# Altium schematic sheet PN532.SchDoc(PN532)
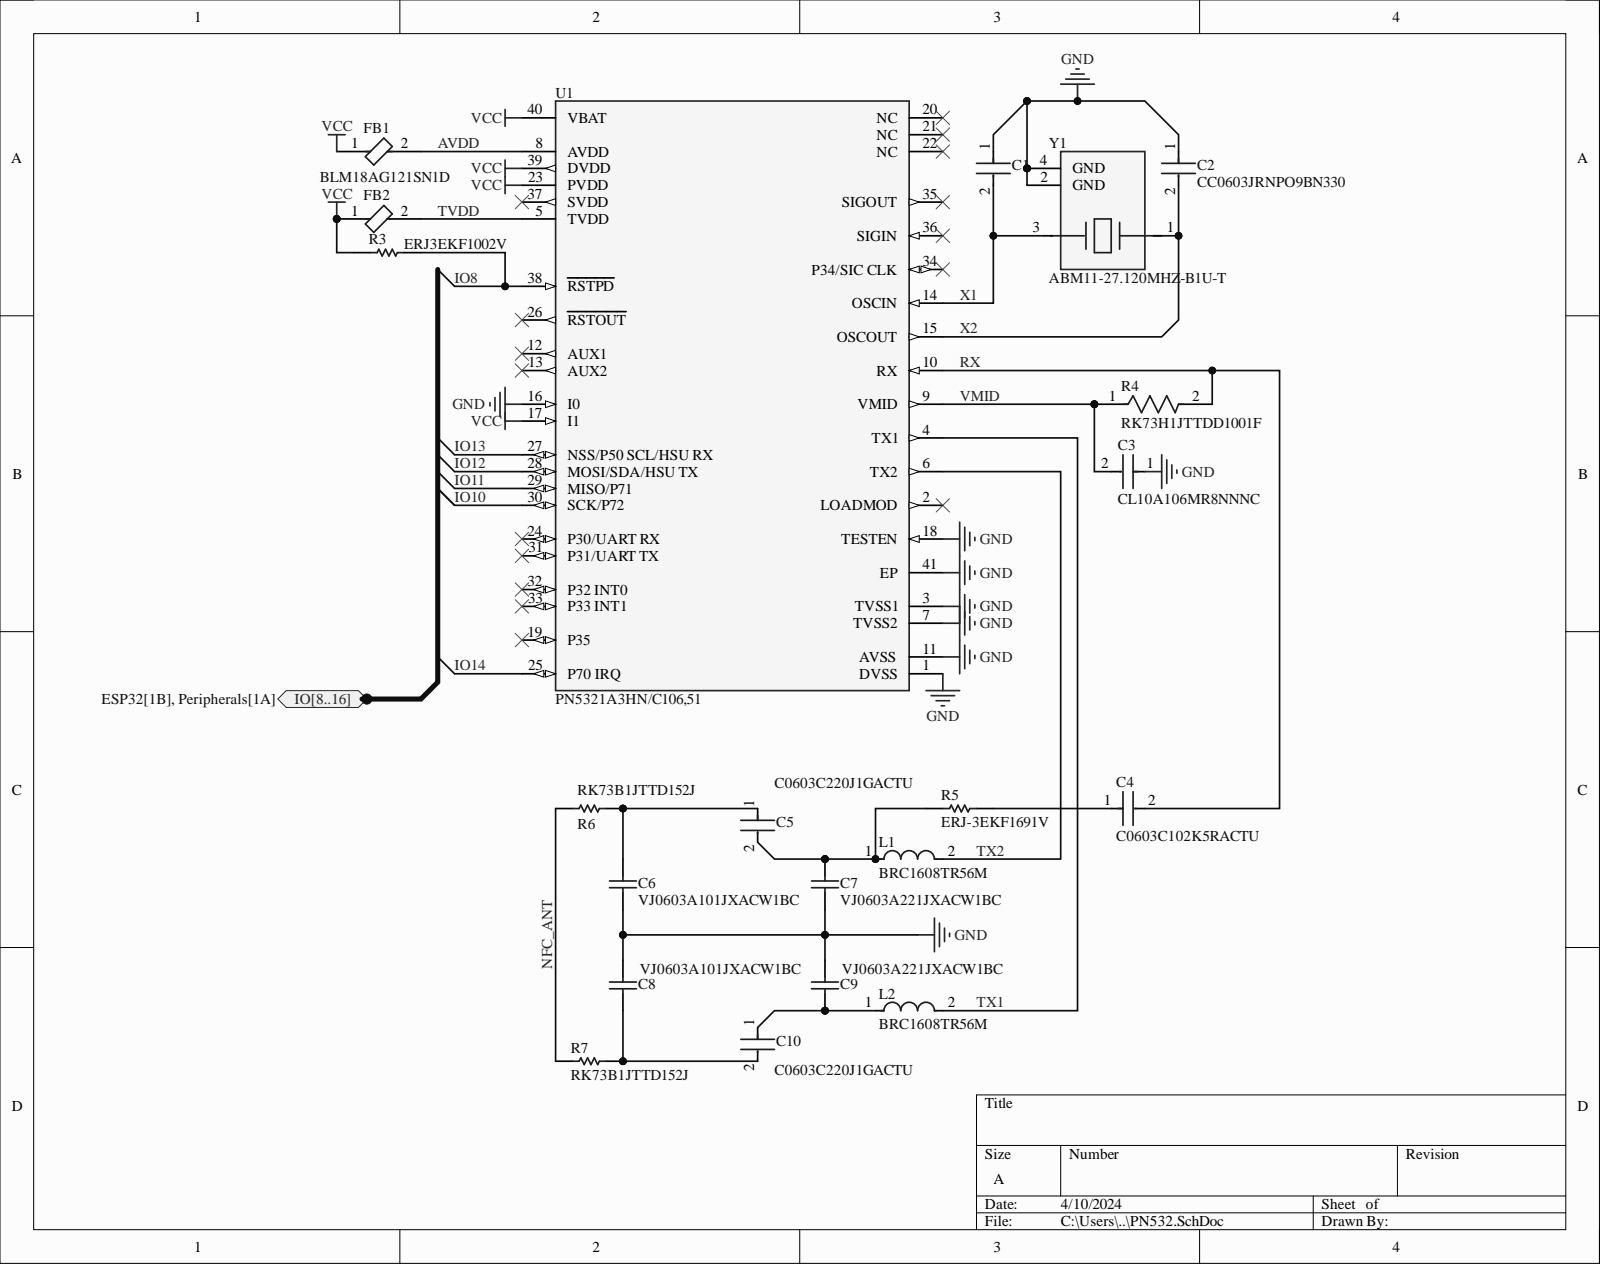
[diagram p0: PN532.SchDoc]

## Parts
C1  CC0603JRNPO9BN330  [FP-CC0603-0_9-IPC_C]
C2  CC0603JRNPO9BN330  [FP-CC0603-0_9-IPC_C]
C3  CL10A106MR8NNNC  [FP-CL10-IPC_C]
C4  C0603C102K5RACTU  [FP-C0603C-CF-MFG]
C5  C0603C220J1GACTU  [FP-C0603C-CF-MFG]
C6  VJ0603A101JXACW1BC  [CAPC1608X95X40ML15T15]
C7  VJ0603A221JXACW1BC  [CAPC1608X95X40ML15T15]
C8  VJ0603A101JXACW1BC  [CAPC1608X95X40ML15T15]
C9  VJ0603A221JXACW1BC  [CAPC1608X95X40ML15T15]
C10  C0603C220J1GACTU  [FP-C0603C-CF-MFG]
FB1  BLM18AG121SN1D  [FP-BLM18-0_15-t0_8-IPC_B]
FB2  BLM18AG121SN1D  [FP-BLM18-0_15-t0_8-IPC_B]
L1  BRC1608TR56M  [FP-BRC1608-MFG]
L2  BRC1608TR56M  [FP-BRC1608-MFG]
R3  ERJ3EKF1002V  [RESC1609X55X30LL15T15]
R4  RK73H1JTTDD1001F  [FP-RK73H1J-IPC_A]
R5  ERJ-3EKF1691V  [RESC1608X55X30NL15T15]
R6  RK73B1JTTD152J  [RESC1608X55X30LL20T10]
R7  RK73B1JTTD152J  [RESC1608X55X30LL20T10]
U1  PN5321A3HN/C106,51  [FP-SOT618-1-MFG]
Y1  ABM11-27.120MHZ-B1U-T  [FP-ABM11-MFG]

## Ports
IO8, IO9, IO10, IO11, IO12, IO13, IO14, IO15, IO16, IO[8..16]

## Nets
GND: C1.1 C2.1 C3.1 C6.1 C7.1 C8.2 C9.2 U1.1(DVSS) U1.3(TVSS1) U1.7(TVSS2) U1.11(AVSS) U1.16(I0) U1.18(TESTEN) U1.41(EP) Y1.2(GND) Y1.4(GND)
VCC: FB1.1 FB2.1 R3.2 U1.17(I1) U1.23(PVDD) U1.39(DVDD) U1.40(VBAT)
AVDD: FB1.2 U1.8(AVDD)
IO8: R3.1 U1.38(RSTPD) ; port IO[8..16]  [also labelled ]
IO10: U1.30(SCK/P72) ; port IO[8..16]  [also labelled ]
IO11: U1.29(MISO/P71) ; port IO[8..16]  [also labelled ]
IO12: U1.28(MOSI/SDA/HSU_TX) ; port IO[8..16]  [also labelled ]
IO13: U1.27(NSS/P50_SCL/HSU_RX) ; port IO[8..16]  [also labelled ]
IO14: U1.25(P70_IRQ) ; port IO[8..16]  [also labelled ]
NFC_ANT: R6.1 R7.1
RX: C4.2 R4.2 U1.10(RX)
TVDD: FB2.2 U1.5(TVDD)
TX1: L2.2 U1.4(TX1)
TX2: L1.2 U1.6(TX2)
VMID: C3.2 R4.1 U1.9(VMID)
X1: C1.2 U1.14(OSCIN) Y1.3
X2: C2.2 U1.15(OSCOUT) Y1.1
NetC4_1: C4.1 R5.1
NetC5_1: C5.1 C6.2 R6.2
NetC5_2: C5.2 C7.2 L1.1 R5.2
NetC8_1: C8.1 C10.2 R7.2
NetC9_1: C9.1 C10.1 L2.1
N00001_9: ; port IO[8..16]
N00001_15: ; port IO[8..16]
N00001_16: ; port IO[8..16]

Not connected: U1 2(LOADMOD) 12(AUX1) 13(AUX2) 19(P35) 20(NC) 21(NC) 22(NC) 24(P30/UART_RX) 26(RSTOUT) 31(P31/UART_TX) 32(P32_INT0) 33(P33_INT1) 34(P34/SIC_CLK) 35(SIGOUT) 36(SIGIN) 37(SVDD)

## Library data of the parts
C1 C2 = CMP-2000-06072-2: CAP CER 33PF 50V C0G/NPO 0603; Dielectric: C0G; Dielectric Material: Ceramic; Insulation Resistance: 100GR; Temperature Coefficient: 30ppm/°C; Tolerance: 5%; Capacitance: 33pF; Case Code (Imperial): 0603; Case Code (Metric): 1608; Case/Package: 0603; Component Kind: Standard; Depth: 0.8mm; Height: 0.8mm; Lead Free: Lead Free; Length: 1.6mm; Library Name: Altium Content Vault; Max Operating Temperature: 125°C; Min Operating Temperature: -55°C; Mount: Surface Mount; Number of Pins: 2; Package Quantity: 1; Packaging: Tape and Reel; Radiation Hardening: No; REACH SVHC: No SVHC; Resistor Type: Ceramic; RoHS Compliant: Yes; Series: CC; Termination: SMD/SMT; Thickness: 0.035inch; Voltage: 50V; Voltage Rating: 50V; Voltage Rating (DC): 50V; Width: 0.031inch
C3 = CMP-13271-001990-1: Cap Ceramic 10uF 4V X5R ±20% Pad SMD 0603 +85°C T/R; Capacitance: 10uF; Case Code (Imperial): 0603; Case Code (Metric): 1608; Case/Package: 0603; Component Kind: Standard; Depth: 0.8mm; Dielectric: X5R; Height: 0.8mm; Lead Free: Lead Free; Length: 1.6mm; Library Name: Altium Content Vault; Max Operating Temperature: 85°C; Min Operating Temperature: -55°C; Mount: Surface Mount; Package Quantity: 1; Packaging: Tape and Reel; Radiation Hardening: No; Thickness: 0.035inch; Tolerance: 20%; Voltage Rating: 4V; Voltage Rating (DC): 4V; Width: 0.031inch
C4 = CMP-2006-03022-3: CAP CER 1000PF 50V X7R 0603; Manufacturer: KEMET; Automotive Grade: Grade 1; Capacitance: 1,000 pF; Material: Ceramic; Temperature Coefficient: 30ppm/°C; Tolerance: 0.1; Value: 1,000 pF; Automotive: Yes; Category: Cap; Component Kind: Standard; ComponentLink2Description: Datasheet; ComponentLink2URL: https://api.kemet.com/component-edge/download/datasheet/C0603C100J5GACAUTO.pdf; Device Class L1: Passive Components; Device Class L2: Capacitors; Device Class L3: Ceramic Capacitors; Digikey Description: CAP CER 1000PF 50V X7R 0603; Height: 0.87mm; Lead Free: Yes; Library Name: Altium Content Vault; Manufacturer Part Number: C0603C102K5RACTU; Mouser Description: Capacitor; Ceramic; Cap; 1000pF; Tol 10%; SMT; Vol-Rtg 50V; X7R; Tape and Reel; Octopart: https://octopart.com/c0603c102k5ractu-kemet-144878; Package: C0603C-CF; RoHS: TRUE; Temperature: -55°C to +125°C; Temperature Characteristic: X7R; Temperature Range High: +125°C; Temperature Range Low: -55°C; Voltage Rating: 50 V
C5 C10 = CMP-2006-03322-2: CAP CER 22PF 100V 5% NP0 0603; Manufacturer: KEMET; Automotive Grade: Grade 1; Material: Ceramic; Temperature Characteristic: C0G; Temperature Coefficient: 30ppm/°C; Tolerance: 0.05; Automotive: Yes; Capacitance: 22 pF; Category: Cap; Component Kind: Standard; ComponentLink2Description: Datasheet; ComponentLink2URL: https://api.kemet.com/component-edge/download/datasheet/C0603C100J5GACAUTO.pdf; Device Class L1: Passive Components; Device Class L2: Capacitors; Device Class L3: Ceramic Capacitors; Digikey Description: CAP CER 22PF 100V 5% NP0 0603; Height: 0.87mm; Lead Free: Yes; Library Name: Altium Content Vault; Manufacturer Part Number: C0603C220J1GACTU; Mouser Description: Multilayer Ceramic Capacitors MLCC - SMD/SMT 100volts 22pF C0G 5%; Octopart: https://octopart.com/c0603c220j1gactu-kemet-9142653; Package: C0603C-CF; RoHS: TRUE; Temperature: -55°C to +125°C; Temperature Range High: +125°C; Temperature Range Low: -55°C; Value: 22 pF; Voltage Rating: 100 V
C6 C8 = CMP-2006-01530-1: Manufacturer: Vitramon; Component Kind: Standard; Library Name: Altium Content Vault; Part Number: VJ0603A101JXACW1BC
C7 C9 = CMP-2006-00030-1: Manufacturer: Vitramon; Component Kind: Standard; Library Name: Altium Content Vault; Part Number: VJ0603A221JXACW1BC
FB1 FB2 = CMP-0686-00439-3: Chip Ferrite Bead, 0603, 120Ω @ 100MHz, 0.18Ω, 25%, 800mA; Current Rating: 500mA; DC Resistance (DCR): 180mR; Impedance: 120R; Material: Steel; Number of Circuits: 1; Shielding: Unshielded; Case Code (Imperial): 0603; Case Code (Metric): 1608; Case/Package: 0603; Component Kind: Standard; Core Material: Ferrite; DC Current: 500mA; DC Resistance-Max: 180mR; Depth: 0.8mm; Frequency: 100MHz; Height: 0.8mm; Lead Free: Lead Free; Length: 1.6mm; Library Name: Altium Content Vault; Max Current Rating: 500mA; Max DC Current: 500mA; Max Operating Temperature: 125°C; Military Standard: Not; Min Operating Temperature: -55°C; Number of Lines: 1; Number of Pins: 2; Package Quantity: 1; Packaging: Tape and Reel; Radiation Hardening: No; REACH SVHC: No SVHC; RoHS Compliant: Yes; Series: BLM18A; Termination: SMD/SMT; Test Frequency: 100MHz; Tolerance: 25%; Width: 0.8mm
L1 L2 = CMP-14484-000012-1: FIXED IND 560NH 760MA 95 MOHM; Core Material: Ferrite; DC Resistance (DCR): 95mR; Inductance Tolerance: 20%; Saturation Current: 1.15A; Self Resonant Frequency: 170MHz; Shielding: Unshielded; Test Frequency: 7.96MHz; Case Code (Imperial): 0603; Case Code (Metric): 1608; Case/Package: 0603; Component Kind: Standard; Composition: Wirewound; Current Rating: 760mA; DC Current: 760mA; Depth: 1mm; Halogen Free: Halogen Free; Height: 0.8mm; Height - Seated (Max): 0.039inch; Inductance: 560nH; Length: 1.6mm; Library Name: Altium Content Vault; Max DC Current: 760mA; Max Operating Temperature: 105°C; Military Standard: Not; Min Operating Temperature: -40°C; Mount: Surface Mount; Number of Pins: 2; Package Quantity: 3000; Packaging: Tape and Reel; Radiation Hardening: No; REACH SVHC: No SVHC; Termination: SMD/SMT; Tolerance: 20%; Width: 0.031inch
R3 = CMP-1012-00623-3: Chip Resistor, 10 KOhm, +/- 1%, 0.1 W, -55 to 155 degC, 0603 (1608 Metric), RoHS, Tape and Reel; Manufacturer: Panasonic; Case\/Package: 0603; Max Power Dissipation: 100mW; Resistance: 10KOhm; Temperature Coefficient: 100ppm\/degreesC; Voltage Rating: 75V; Case Code (Imperial): 0603; Case Code (Metric): 1608; Component Kind: Standard; Composition: ThickFilm; Contact Plating: Tin; Datasheet URL: https://industrial.panasonic.com/cdbs/www-data/pdf/RDA0000/AOA0000C304.pdf; Datasheet Version: 02/2017; Depth: 0.8mm; Height: 0.45mm; Lead Free: LeadFree; Length: 1.6mm; Library Name: Altium Content Vault; Manufacturer URL: http://www.panasonic.com/; Max Operating Temperature: 155degC; Military Standard: Not; Min Operating Temperature: -55degC; Mount: SurfaceMount; Mounting Technology: SurfaceMount; Number of Pins: 2; Number of Terminations: 2; Package Description: 2-Pin Surface Mount Device, Body 1.6 x 0.85 mm; Package Quantity: 1; Package Reference: 603; Packaging: TapeandReel; Power Rating: 100mW; Radiation Hardening: No; REACH SVHC: NoSVHC; RoHSCompliant: true; Termination: SMD/SMT; Tolerance: 1%; Voltage Rating (DC): 75V; Width: 0.031inch
R4 = CMP-2000-01750-2: 1kΩ ±1% 0.125W Chip Resistor 0603 Thick Film AEC-Q200 Qualified; Power Rating: 100mW; Resistance: 1kR; Temperature Coefficient: 100ppm/°C; Voltage Rating: 50V; Case Code (Imperial): 0603; Case Code (Metric): 1608; Case/Package: 0603; Component Kind: Standard; Construction: Rectangular; Depth: 0.8mm; Height: 0.45mm; Lead Free: Lead Free; Length: 1.6mm; Library Name: Altium Content Vault; Max Operating Temperature: 155°C; Military Standard: Not; Min Operating Temperature: -55°C; Package Quantity: 10000; Packaging: Tape and Reel; Resistor Type: Thick Film; RoHS Compliant: Yes; Series: RK73H; Termination: SMD/SMT; Tolerance: 1%; Width: 0.8mm
R5 = CMP-1012-00534-2: Manufacturer: Panasonic; Component Kind: Standard; Library Name: Altium Content Vault; Part Number: ERJ-3EKF1691V
R6 R7 = CMP-2000-01875-1: Manufacturer: KOA Speer; Component Kind: Standard; Library Name: Altium Content Vault; Part Number: RK73B1JTTD152J
U1 = CMP-10150-000009-1: IC RFID RDR/TRAN 13.56MZ 40HVQFN; Manufacturer: NXP Semiconductors; Data Rate: 424kbits/s; Interface: I2C,SPI,UART; Max Frequency: 13.56MHz; Max Junction Temp: +125°C; Max Power Dissipation: 500mW; Max Supply Voltage: 5.5V; Min Supply Voltage: 1.6V; Nominal Supply Current: 0.5-60mA; Number Of Adc Channels: 2; Number Of Gpio: 9; Standoff Height: 0mm; Transmitting Current: 60mA; Ambient Temperature Range High: +85°C; Ambient Temperature Range Low: -30°C; Automotive: No; Category: IC; Component Kind: Standard; ComponentLink2Description: Datasheet; ComponentLink2URL: https://www.nxp.com/docs/en/nxp/data-sheets/PN532_C1.pdf; Device Class L1: Integrated Circuits (ICs); Device Class L2: RF Semiconductors and Devices; Device Class L3: Transceivers; Digikey Description: IC RFID RDR/TRAN 13.56MZ 40HVQFN; Footprint Url: https://www.nxp.com/docs/en/package-information/SOT618-1.pdf; Height: 1mm; Ipc Land Pattern Name: QFN50P600X600X85-40; Lead Free: Yes; Library Name: Altium Content Vault; Manufacturer Part Number: PN5321A3HN/C106,51; Mouser Description: PN5321A3HN Series 13.56 MHz RF Reader Analog and Digital Combo - HVQFN-40; Octopart: https://octopart.com/pn5321a3hn%2Fc106%2C51-nxp+semiconductors-18453768; Package: SOT618-1; RoHS: TRUE; Temperature: -40°C to +125°C; Temperature Range High: +125°C; Temperature Range Low: -40°C
Y1 = CMP-27762-005978-1: Crystal 27.12MHz ±10ppm 10pF SMD-4 2mm x 1.6mm; Component Kind: Standard; Library Name: Altium Content Vault
